# Altium schematic sheet main.SchDoc(main)
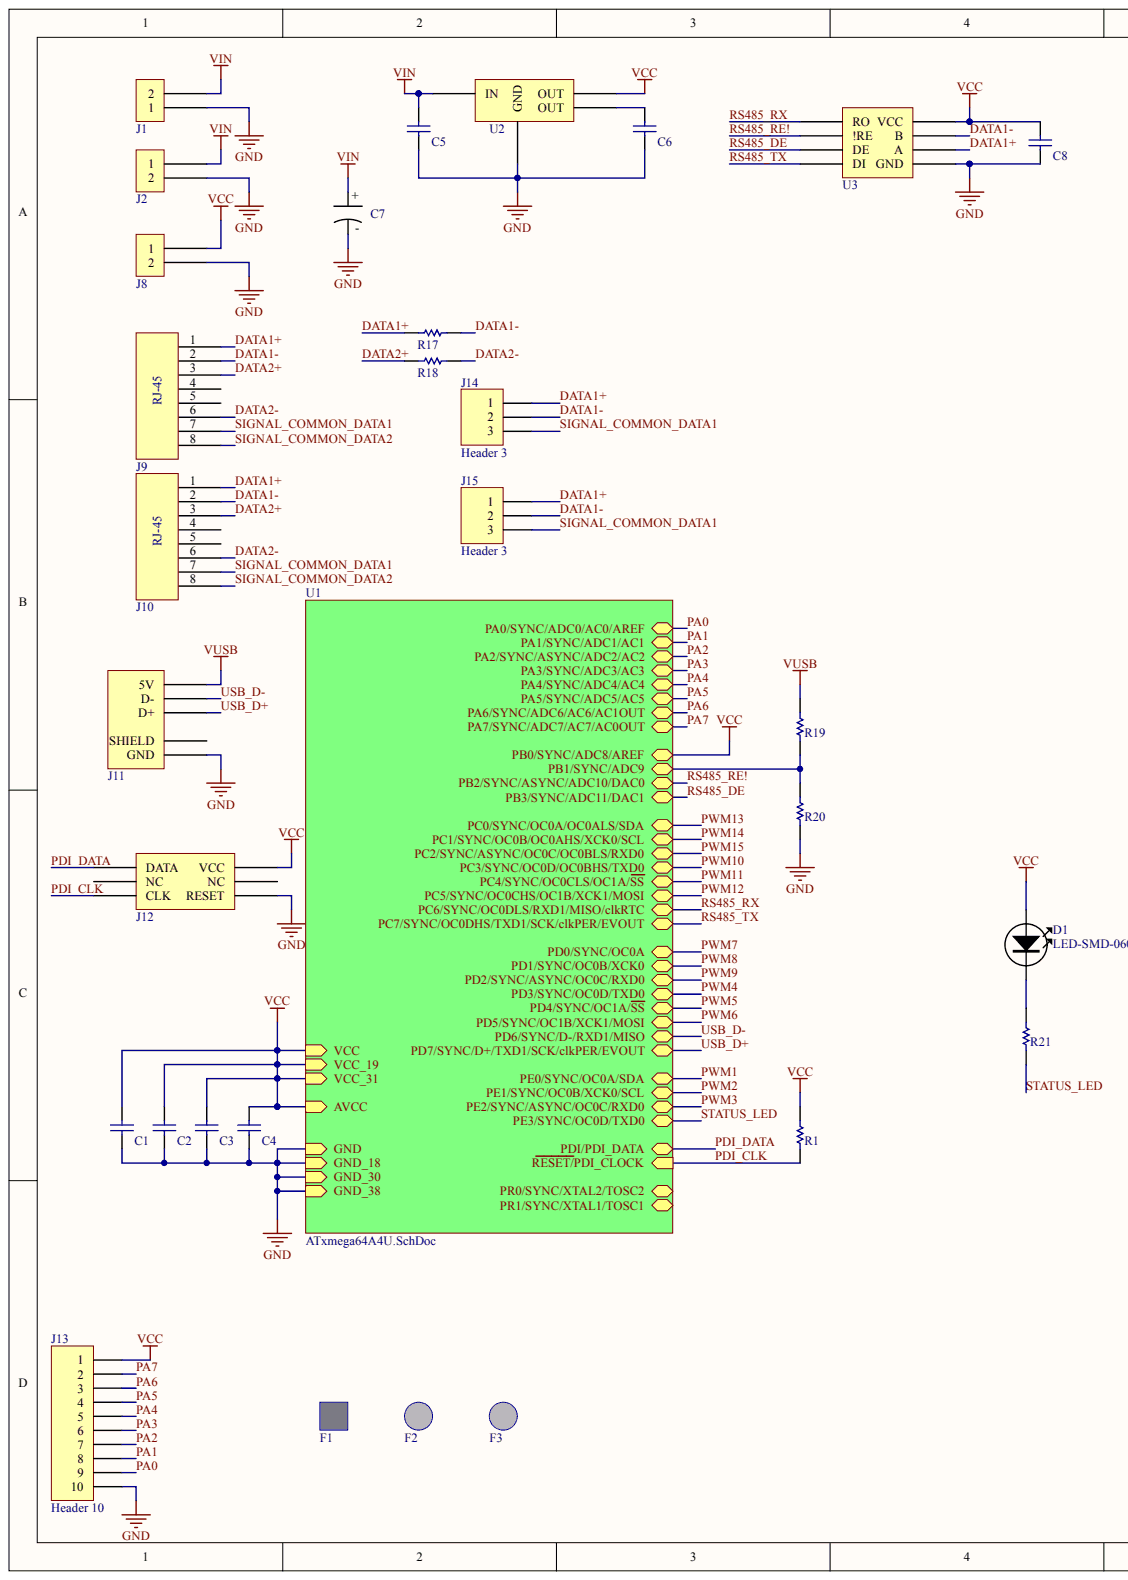
[diagram p0: main.SchDoc, left]
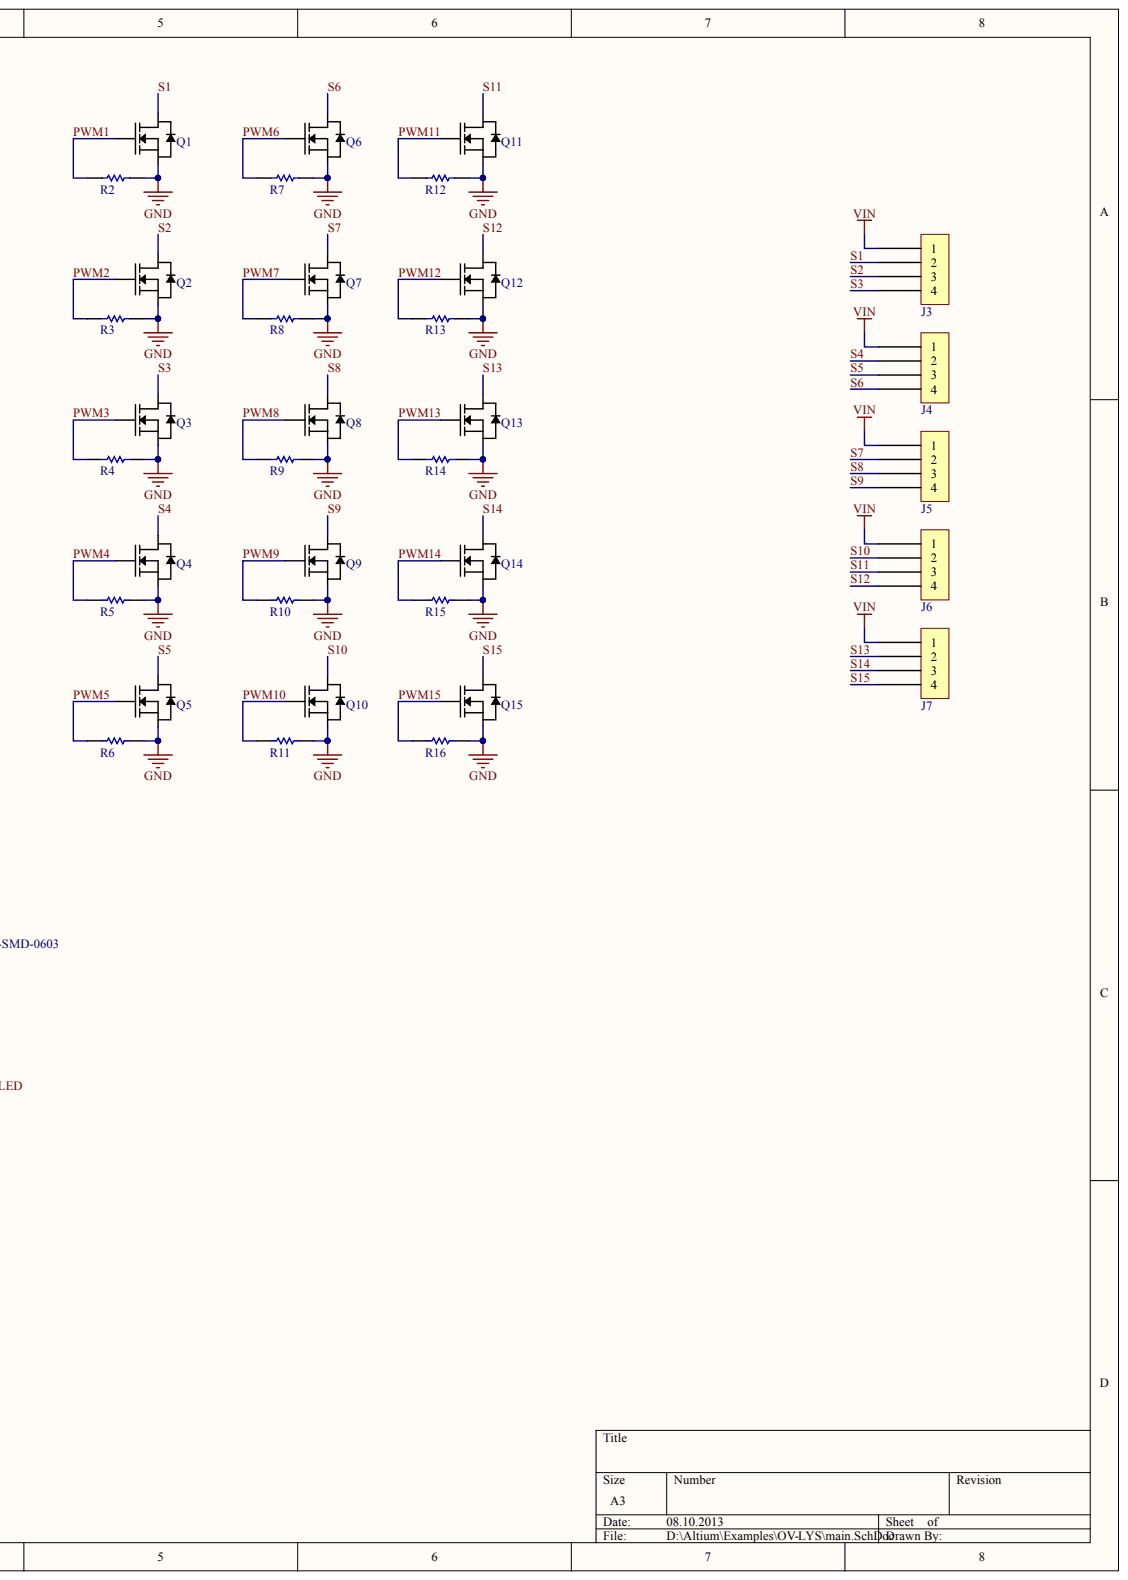
[diagram p1: main.SchDoc, right]

## Parts
C1  CAP 3.3nF 10V 0805(2012)  (value not drawn)
C2  CAP 3.3nF 10V 0805(2012)  (value not drawn)
C3  CAP 3.3nF 10V 0805(2012)  (value not drawn)
C4  CAP 3.3nF 10V 0805(2012)  (value not drawn)
C5  CAP 1uF 16V 1210(3225)  (value not drawn)
C6  CAP 10uF 6.3V 1210(3225)  (value not drawn)
C7  ELECTROLYTIC-CAP-220uF  (value not drawn)
C8  CAP 3.3nF 10V 0805(2012)  (value not drawn)
D1  LED-SMD-0603
F1  Fiducial square  (value not drawn)
F2  Fiducial round  (value not drawn)
F3  Fiducial round  (value not drawn)
J1  PLUGGABLE-TERMINAL-2  (value not drawn)
J2  PLUGGABLE-TERMINAL-2  (value not drawn)
J3  PLUGGABLE-TERMINAL-4  (value not drawn)
J4  PLUGGABLE-TERMINAL-4  (value not drawn)
J5  PLUGGABLE-TERMINAL-4  (value not drawn)
J6  PLUGGABLE-TERMINAL-4  (value not drawn)
J7  PLUGGABLE-TERMINAL-4  (value not drawn)
J8  PLUGGABLE-TERMINAL-2  (value not drawn)
J9  RJ-45 Connector  (value not drawn)
J10  RJ-45 Connector  (value not drawn)
J11  USB-MINI  (value not drawn)
J12  PDI
J13  Header 10
J14  Header 3
J15  Header 3
Q1  TSM2314CX  (value not drawn)
Q2  TSM2314CX  (value not drawn)
Q3  TSM2314CX  (value not drawn)
Q4  TSM2314CX  (value not drawn)
Q5  TSM2314CX  (value not drawn)
Q6  TSM2314CX  (value not drawn)
Q7  TSM2314CX  (value not drawn)
Q8  TSM2314CX  (value not drawn)
Q9  TSM2314CX  (value not drawn)
Q10  TSM2314CX  (value not drawn)
Q11  TSM2314CX  (value not drawn)
Q12  TSM2314CX  (value not drawn)
Q13  TSM2314CX  (value not drawn)
Q14  TSM2314CX  (value not drawn)
Q15  TSM2314CX  (value not drawn)
R1  10K 1% 0805(2012)  (value not drawn)
R2  10K 1% 0805(2012)  (value not drawn)
R3  10K 1% 0805(2012)  (value not drawn)
R4  10K 1% 0805(2012)  (value not drawn)
R5  10K 1% 0805(2012)  (value not drawn)
R6  10K 1% 0805(2012)  (value not drawn)
R7  10K 1% 0805(2012)  (value not drawn)
R8  10K 1% 0805(2012)  (value not drawn)
R9  10K 1% 0805(2012)  (value not drawn)
R10  10K 1% 0805(2012)  (value not drawn)
R11  10K 1% 0805(2012)  (value not drawn)
R12  10K 1% 0805(2012)  (value not drawn)
R13  10K 1% 0805(2012)  (value not drawn)
R14  10K 1% 0805(2012)  (value not drawn)
R15  10K 1% 0805(2012)  (value not drawn)
R16  10K 1% 0805(2012)  (value not drawn)
R17  120R 1% 0805(2012)  (value not drawn)
R18  120R 1% 0805(2012)  (value not drawn)
R19  10K 1% 0805(2012)  (value not drawn)
R20  15K 1% 0805(2012)  (value not drawn)
R21  200R 1% 0805(2012)  (value not drawn)
U2  TLV1117  (value not drawn)
U3  MAX3485  (value not drawn)

## Nets
GND: C1.1 C2.1 C3.1 C4.1 C5.1 C6.1 C7.2 C8.1 J1.1 J2.2 J8.2 J11.5(GND) J12.6(RESET) J13.10 Q1.2 Q2.2 Q3.2 Q4.2 Q5.2 Q6.2 Q7.2 Q8.2 Q9.2 Q10.2 Q11.2 Q12.2 Q13.2 Q14.2 Q15.2 R2.2 R3.2 R4.2 R5.2 R6.2 R7.2 R8.2 R9.2 R10.2 R11.2 R12.2 R13.2 R14.2 R15.2 R16.2 R20.1 U2.1(GND) U3.5(GND)
VCC: C1.2 C2.2 C3.2 C4.2 C8.2 D1.1 J8.1 J12.2(VCC) J13.1 R1.2 U2.4(OUT) U3.8(VCC)
VIN: C5.2 C7.1 J1.2 J2.1 J3.1 J4.1 J5.1 J6.1 J7.1 U2.3(IN)
VUSB: J11.1(5V) R19.2
DATA1+: J9.1 J10.1 J14.1 J15.1 R17.1 U3.6(A)
DATA1-: J9.2 J10.2 J14.2 J15.2 R17.2 U3.7(B)
DATA2+: J9.3 J10.3 R18.1
DATA2-: J9.6 J10.6 R18.2
PA0: J13.9
PA1: J13.8
PA2: J13.7
PA3: J13.6
PA4: J13.5
PA5: J13.4
PA6: J13.3
PA7: J13.2
PDI_CLK: J12.5(CLK) R1.1
PDI_DATA: J12.1(DATA)
PWM1: Q1.3 R2.1
PWM2: Q2.3 R3.1
PWM3: Q3.3 R4.1
PWM4: Q4.3 R5.1
PWM5: Q5.3 R6.1
PWM6: Q6.3 R7.1
PWM7: Q7.3 R8.1
PWM8: Q8.3 R9.1
PWM9: Q9.3 R10.1
PWM10: Q10.3 R11.1
PWM11: Q11.3 R12.1
PWM12: Q12.3 R13.1
PWM13: Q13.3 R14.1
PWM14: Q14.3 R15.1
PWM15: Q15.3 R16.1
RS485_DE: U3.3(DE)
RS485_RE!: U3.2(!RE)
RS485_RX: U3.1(RO)
RS485_TX: U3.4(DI)
S1: J3.2 Q1.1
S2: J3.3 Q2.1
S3: J3.4 Q3.1
S4: J4.2 Q4.1
S5: J4.3 Q5.1
S6: J4.4 Q6.1
S7: J5.2 Q7.1
S8: J5.3 Q8.1
S9: J5.4 Q9.1
S10: J6.2 Q10.1
S11: J6.3 Q11.1
S12: J6.4 Q12.1
S13: J7.2 Q13.1
S14: J7.3 Q14.1
S15: J7.4 Q15.1
SIGNAL_COMMON_DATA1: J9.7 J10.7 J14.3 J15.3
SIGNAL_COMMON_DATA2: J9.8 J10.8
STATUS_LED: R21.1
USB_D+: J11.3(D+)
USB_D-: J11.2(D-)
NetC6_2: C6.2 U2.2(OUT)
NetD1_2: D1.2 R21.2
NetJ9_4: J9.4
NetJ9_5: J9.5
NetJ10_4: J10.4
NetJ10_5: J10.5
NetJ11_0: J11.0(SHIELD)
NetJ12_3: J12.3(NC)
NetJ12_4: J12.4(NC)
NetR19_1: R19.1 R20.2
PR0/SYNC/XTAL2/TOSC2:
PR1/SYNC/XTAL1/TOSC1:

## Library data of the parts
C1-C4 C8 = (no symbol name): Case-Metric: 2012; Case-EIA: 0805; Tolerance: ±1%; Rated Voltage: 10 V; Value: 3.3nF; Technology: SMT; Max Thickness: 1.45 mm
C5 = (no symbol name): Case-Metric: 3225; Case-EIA: 1210; Tolerance: ±5%; Max Thickness: 1.45 mm; Technology: SMT; Rated Voltage: 16 V; Value: 1uF
C6 = (no symbol name): Tolerance: ±10%; Case-EIA: 1210; Case-Metric: 3225; Technology: SMT; Rated Voltage: 6.3 V; Value: 10uF; Max Thickness: 1.7 mm
J9-J11 = (no symbol name): Tolerance: 1; Temp. Coefficent: ±100; Technology: SMT
R1-R16 R19 = (no symbol name): Value: 10000.00 Ohm; Case-Metric: 2012; Case-EIA: 0805; Power: 0.125 W; Tolerance: 1 %; Technology: SMT
R17 R18 = (no symbol name): Case-Metric: 2012; Case-EIA: 0805; Value: 120.00 Ohm; Power: 0.125 W; Tolerance: 1 %; Technology: SMT
R20 = (no symbol name): Case-Metric: 2012; Case-EIA: 0805; Value: 15000.00 Ohm; Power: 0.125 W; Tolerance: 1 %; Technology: SMT
R21 = (no symbol name): Case-EIA: 0805; Case-Metric: 2012; Value: 200.00 Ohm; Power: 0.125 W; Technology: SMT; Tolerance: 1 %
C7 D1 F1-F3 J1-J8 J12-J15 Q1-Q15 U2 U3 = (no symbol name)
  J13-J15: LatestRevisionDate: 17-Jul-2002; LatestRevisionNote: Re-released for DXP Platform.; Publisher: Altium Limited
